# Altium schematic sheet ADC.SchDoc(ADC)
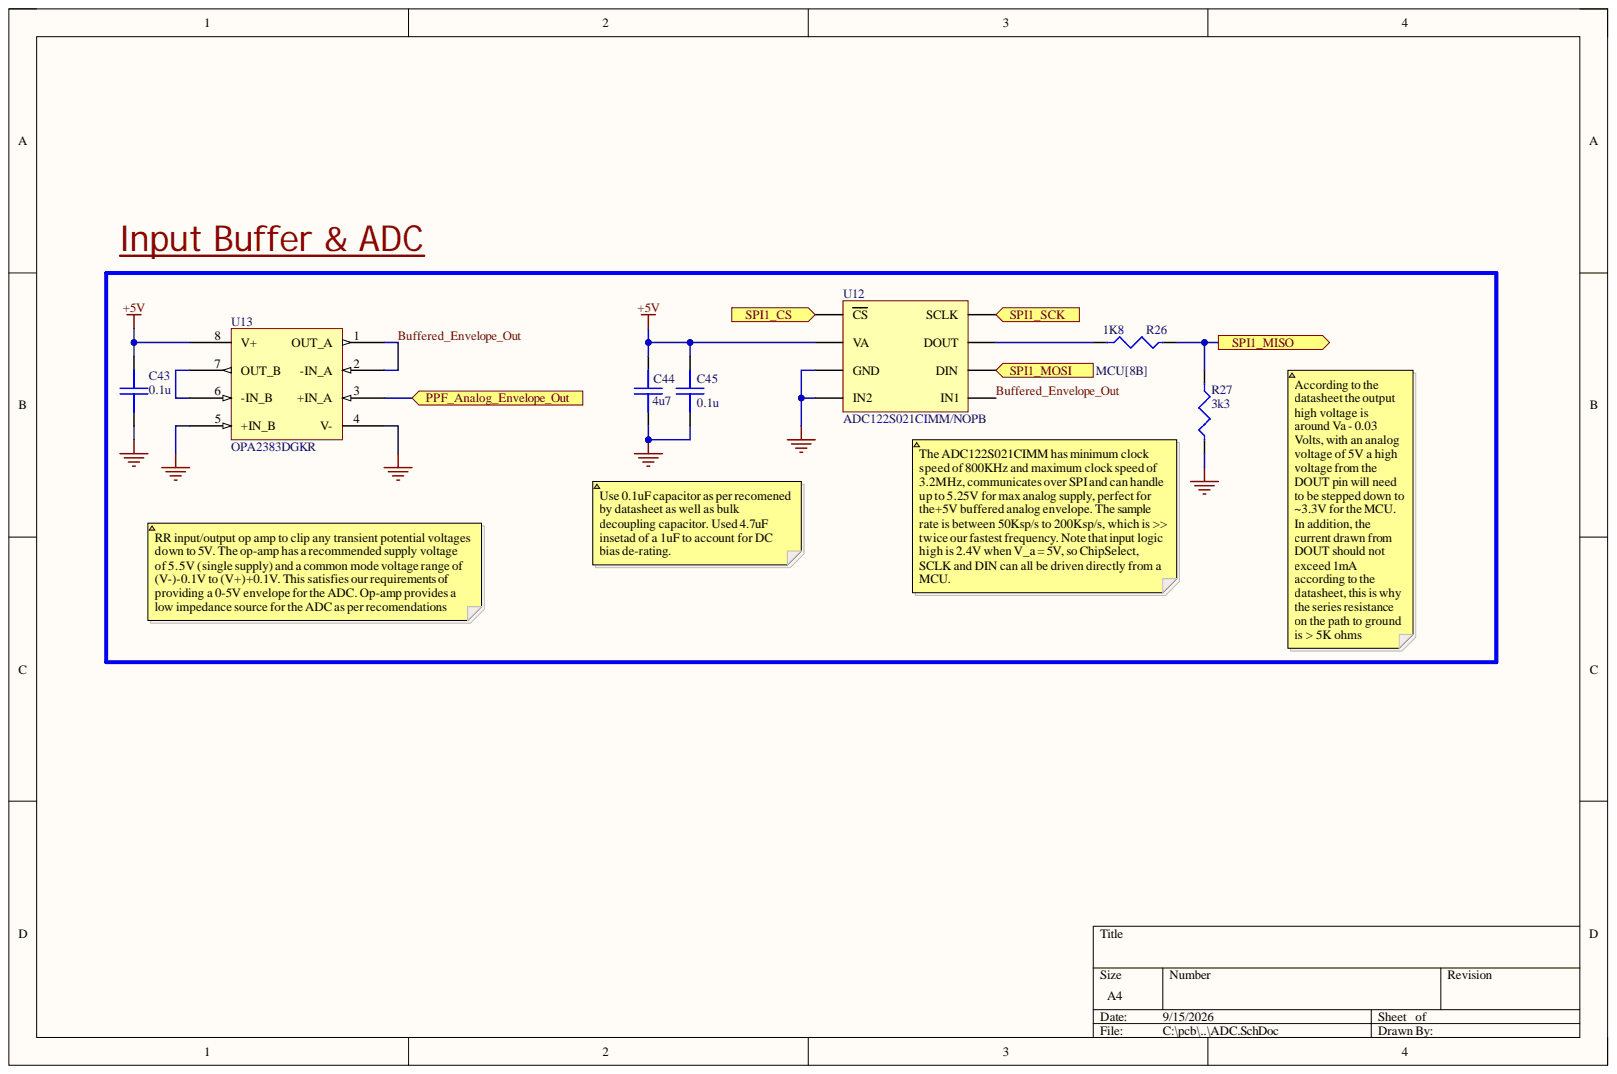
[diagram p0: ADC.SchDoc]

## Parts
C43  0.1u  [C0603]
C44  4u7  [C0805]
C45  0.1u  [C0603]
R26  1K8  [R0603]
R27  3k3  [R0603]
U12  ADC122S021CIMM/NOPB  [ADC122S021CIMM/NOPB]
U13  OPA2383DGKR  [OPA2383DGKR]

## Ports
PPF_Analog_Envelope_Out, SPI1_CS, SPI1_MISO, SPI1_MOSI, SPI1_SCK

## Nets
+5V: C43.2 C44.2 C45.2 U12.2(VA) U13.8(V+)
GND: C43.1 C44.1 C45.1 R27.1 U12.3(GND) U12.4(IN2) U13.4(V-) U13.5(+IN_B)
Buffered_Envelope_Out: U12.5(IN1) U13.1(OUT_A) U13.2(-IN_A)
PPF_Analog_Envelope_Out: U13.3(+IN_A) ; port PPF_Analog_Envelope_Out
SPI1_CS: U12.1(CS) ; port SPI1_CS
SPI1_MISO: R26.1 R27.2 ; port SPI1_MISO
SPI1_MOSI: U12.6(DIN) ; port SPI1_MOSI
SPI1_SCK: U12.8(SCLK) ; port SPI1_SCK
NetR26_2: R26.2 U12.7(DOUT)
NetU13_6: U13.6(-IN_B) U13.7(OUT_B)

## Library data of the parts
C43 C45 = C0603C104K5RACAUTO: Multilayer Ceramic Capacitors MLCC - SMD/SMT 50V 0.1uF X7R 0603 10% AEC-Q200; Manufacturer: Yageo Group; Dissipation Factor: 2.5%; Temperature Coefficient: 30ppm/°C; Value: 100nF; Case Code (Imperial): 0603; Case Code (Metric): 1608; Case/Package: 0603; Category: Ceramic Capacitors; China RoHS: Compliant; Component Kind: Standard; ComponentLink1Description: https://datasheet.octopart.com:443/C0603C104K5RACAUTO-KEMET-datasheet-178087915.pdf?src-supplier=Farnell; ComponentLink1URL: https://datasheet.octopart.com:443/C0603C104K5RACAUTO-KEMET-datasheet-178087915.pdf?src-supplier=Farnell; ComponentLink2Description: https://datasheet.octopart.com:443/C0603C104K5RACAUTO-KEMET-datasheet-140480346.pdf?src-supplier=Farnell; ComponentLink2URL: https://datasheet.octopart.com:443/C0603C104K5RACAUTO-KEMET-datasheet-140480346.pdf?src-supplier=Farnell; ComponentLink3Description: https://datasheet.octopart.com:443/C0603C104K5RACAUTO-KEMET-datasheet-138896278.pdf?src-supplier=Farnell; ComponentLink3URL: https://datasheet.octopart.com:443/C0603C104K5RACAUTO-KEMET-datasheet-138896278.pdf?src-supplier=Farnell; ComponentLink4Description: https://datasheet.octopart.com:443/C0603C104K5RACAUTO-KEMET-datasheet-101575653.pdf?src-supplier=Farnell; ComponentLink4URL: https://datasheet.octopart.com:443/C0603C104K5RACAUTO-KEMET-datasheet-101575653.pdf?src-supplier=Farnell; ComponentLink5Description: https://datasheet.octopart.com:443/C0603C104K5RACAUTO-KEMET-datasheet-169773475.pdf?src-supplier=Farnell; ComponentLink5URL: https://datasheet.octopart.com:443/C0603C104K5RACAUTO-KEMET-datasheet-169773475.pdf?src-supplier=Farnell; ComponentLink6Description: https://datasheet.octopart.com:443/C0603C104K5RAC-AUTO-Kemet-datasheet-11517047.pdf?src-supplier=Farnell; ComponentLink6URL: https://datasheet.octopart.com:443/C0603C104K5RAC-AUTO-Kemet-datasheet-11517047.pdf?src-supplier=Farnell; ComponentLink7Description: https://datasheet.octopart.com:443/C0603C104K5RACAUTO-KEMET-datasheet-137357764.pdf?src-supplier=Upverter; ComponentLink7URL: https://datasheet.octopart.com:443/C0603C104K5RACAUTO-KEMET-datasheet-137357764.pdf?src-supplier=Upverter; ComponentLink8Description: https://datasheet.octopart.com:443/C0603C104K5RACAUTO-Kemet-datasheet-17277841.pdf?src-supplier=Newark; ComponentLink8URL: https://datasheet.octopart.com:443/C0603C104K5RACAUTO-Kemet-datasheet-17277841.pdf?src-supplier=Newark; ComponentLink9Description: https://datasheet.octopart.com:443/C0603C104K5RAC-AUTO-Kemet-datasheet-10850653.pdf?src-supplier=Newark; ComponentLink9URL: https://datasheet.octopart.com:443/C0603C104K5RAC-AUTO-Kemet-datasheet-10850653.pdf?src-supplier=Newark; ComponentLink10Description: https://datasheet.octopart.com:443/C0603C104K5RACAUTO-KEMET-datasheet-176289396.pdf?src-supplier=JRH+Electronics; ComponentLink10URL: https://datasheet.octopart.com:443/C0603C104K5RACAUTO-KEMET-datasheet-176289396.pdf?src-supplier=JRH+Electronics; ComponentLink11Description: https://datasheet.octopart.com:443/C0603C104K5RACAUTO-KEMET-datasheet-153410967.pdf?src-supplier=Electro+Sonic; ComponentLink11URL: https://datasheet.octopart.com:443/C0603C104K5RACAUTO-KEMET-datasheet-153410967.pdf?src-supplier=Electro+Sonic; ComponentLink12Description: https://datasheet.octopart.com:443/C0603C104K5RACAUTO-KEMET-datasheet-142166320.pdf?src-supplier=Electro+Sonic; ComponentLink12URL: https://datasheet.octopart.com:443/C0603C104K5RACAUTO-KEMET-datasheet-142166320.pdf?src-supplier=Electro+Sonic; ComponentLink13Description: https://datasheet.octopart.com:443/C0603C104K5RACAUTO-Kemet-datasheet-66076775.pdf?src-supplier=element14+APAC; ComponentLink13URL: https://datasheet.octopart.com:443/C0603C104K5RACAUTO-Kemet-datasheet-66076775.pdf?src-supplier=element14+APAC; ComponentLink14Description: https://datasheet.octopart.com:443/C0603C104K5RACAUTO-Kemet-datasheet-66204749.pdf?src-supplier=element14+APAC; ComponentLink14URL: https://datasheet.octopart.com:443/C0603C104K5RACAUTO-Kemet-datasheet-66204749.pdf?src-supplier=element14+APAC; ComponentLink15Description: https://datasheet.octopart.com:443/C0603C104K5RACAUTO-Kemet-datasheet-66534724.pdf?src-supplier=element14+APAC; ComponentLink15URL: https://datasheet.octopart.com:443/C0603C104K5RACAUTO-Kemet-datasheet-66534724.pdf?src-supplier=element14+APAC; ComponentLink16Description: https://datasheet.octopart.com:443/C0603C104K5RACAUTO-KEMET-datasheet-83968784.pdf?src-supplier=TME; ComponentLink16URL: https://datasheet.octopart.com:443/C0603C104K5RACAUTO-KEMET-datasheet-83968784.pdf?src-supplier=TME; ComponentLink17Description: https://datasheet.octopart.com:443/C0603C104K5RACAUTO-KEMET-datasheet-83186888.pdf?src-supplier=TME; ComponentLink17URL: https://datasheet.octopart.com:443/C0603C104K5RACAUTO-KEMET-datasheet-83186888.pdf?src-supplier=TME; ComponentLink18Description: https://datasheet.octopart.com/C0603C104K5RACAUTO-KEMET-datasheet-84169754.pdf; ComponentLink18URL: https://datasheet.octopart.com/C0603C104K5RACAUTO-KEMET-datasheet-84169754.pdf; ComponentLink19Description: https://datasheet.octopart.com:443/C0603C104K5RACAUTO-KEMET-datasheet-189767472.pdf?src-supplier=ODG+(Origin+Data+Global); ComponentLink19URL: https://datasheet.octopart.com:443/C0603C104K5RACAUTO-KEMET-datasheet-189767472.pdf?src-supplier=ODG+(Origin+Data+Global); ComponentLink20Description: http://sigma.octopart.com:80/conflict_mineral_statement/Yageo-company-3.pdf?src-supplier=Compliance+Statements; ComponentLink20URL: http://sigma.octopart.com:80/conflict_mineral_statement/Yageo-company-3.pdf?src-supplier=Compliance+Statements; ComponentLink21Description: http://sigma.octopart.com:80/rohs_statement/Yageo-company-2.pdf?src-supplier=Compliance+Statements; ComponentLink21URL: http://sigma.octopart.com:80/rohs_statement/Yageo-company-2.pdf?src-supplier=Compliance+Statements; Contact Plating: Tin; Country of Origin: Mexico; Depth: 800µm; Dielectric: X7R; Dielectric Material: Ceramic; Export Control Classification Number (ECCN) Code: EAR99; Harmonized Tariff Schedule (HTS) Code: 8532.24.00.20; Height: 800µm; Introduction Date: 2011-07-27; Length: 1.6mm; Lifecycle Status: Production; Manufacturer Part Number: C0603C104K5RACAUTO; Material: Ceramic; Max Operating Temperature: 125°C; Min Operating Temperature: -55°C; Mounting Technology: Surface Mount; Number of Terminals: 2; Packaging: Cut Tape; Pricing: 1=0.15, 10=0.083, 50=0.0574, 100=0.0497, 500=0.0367 (USD); Radiation Hardening: No; Ratings: AEC-Q200; REACH SVHC: Yes; RoHS: Compliant; Schedule B: 8532240020, 8532240020|8532240020, 8532240020|8532240020|8532240020, 8532240020|8532240020|8532240020|8532240020; Stock: 1408607; Supplier: DigiKey; Supplier Part Number: 399-6856-6-ND; Termination: SMD/SMT; Thickness: 863.6µm; Tolerance: 10%; Voltage Rating: 50V; Voltage Rating (DC): 50V; Width: 800µm; Library Name: Tremelo_Pedal.SchLib
C44 = TMK212AB7475KG-T: 25V 4.7uF X7R 卤10% 0805 Multilayer Ceramic Capacitors MLCC - SMD/SMT ROHS; Manufacturer: TAIYO YUDEN; Manufacturer Package Identifier: 0805 (2012 metric); Tolerance: 10%; Value: 4.7µF; Case Code (Imperial): 0805; Case/Package: 0805; Category: Ceramic Capacitors; Component Kind: Standard; ComponentLink1Description: https://datasheet.octopart.com:443/TMK212AB7475KG-T-Taiyo-Yuden-datasheet-181961943.pdf?src-supplier=Newark; ComponentLink1URL: https://datasheet.octopart.com:443/TMK212AB7475KG-T-Taiyo-Yuden-datasheet-181961943.pdf?src-supplier=Newark; ComponentLink2Description: https://datasheet.octopart.com:443/TMK212AB7475KG-T-Taiyo-Yuden-datasheet-23715841.pdf?src-supplier=Newark; ComponentLink2URL: https://datasheet.octopart.com:443/TMK212AB7475KG-T-Taiyo-Yuden-datasheet-23715841.pdf?src-supplier=Newark; ComponentLink3Description: https://datasheet.octopart.com:443/TMK212AB7475KG-T-Taiyo-Yuden-datasheet-151625811.pdf?src-supplier=Newark; ComponentLink3URL: https://datasheet.octopart.com:443/TMK212AB7475KG-T-Taiyo-Yuden-datasheet-151625811.pdf?src-supplier=Newark; ComponentLink4Description: https://datasheet.octopart.com:443/TMK212AB7475KG-T-Taiyo-Yuden-datasheet-10851928.pdf?src-supplier=element14+APAC; ComponentLink4URL: https://datasheet.octopart.com:443/TMK212AB7475KG-T-Taiyo-Yuden-datasheet-10851928.pdf?src-supplier=element14+APAC; ComponentLink5Description: https://datasheet.octopart.com:443/TMK212AB7475KG-T-Taiyo-Yuden-datasheet-154810072.pdf?src-supplier=Master+Electronics; ComponentLink5URL: https://datasheet.octopart.com:443/TMK212AB7475KG-T-Taiyo-Yuden-datasheet-154810072.pdf?src-supplier=Master+Electronics; ComponentLink6Description: https://datasheet.octopart.com:443/TMK212AB7475KG-T-Taiyo-Yuden-datasheet-156880150.pdf?src-supplier=Future+Electronics; ComponentLink6URL: https://datasheet.octopart.com:443/TMK212AB7475KG-T-Taiyo-Yuden-datasheet-156880150.pdf?src-supplier=Future+Electronics; ComponentLink7Description: https://datasheet.octopart.com:443/TMK212AB7475KG-T-Taiyo-Yuden-datasheet-133511635.pdf?src-supplier=Future+Electronics; ComponentLink7URL: https://datasheet.octopart.com:443/TMK212AB7475KG-T-Taiyo-Yuden-datasheet-133511635.pdf?src-supplier=Future+Electronics; ComponentLink8Description: https://datasheet.octopart.com:443/TMK212AB7475KG-T-Taiyo-Yuden-datasheet-99784822.pdf?src-supplier=Future+Electronics; ComponentLink8URL: https://datasheet.octopart.com:443/TMK212AB7475KG-T-Taiyo-Yuden-datasheet-99784822.pdf?src-supplier=Future+Electronics; ComponentLink9Description: https://datasheet.octopart.com:443/TMK212AB7475KG-T-Taiyo-Yuden-datasheet-138738940.pdf?src-supplier=Taiyo+Yuden+SCT; ComponentLink9URL: https://datasheet.octopart.com:443/TMK212AB7475KG-T-Taiyo-Yuden-datasheet-138738940.pdf?src-supplier=Taiyo+Yuden+SCT; ComponentLink10Description: https://datasheet.octopart.com:443/TMK212AB7475KG-T-Taiyo-Yuden-datasheet-62109611.pdf?src-supplier=Electro+Sonic; ComponentLink10URL: https://datasheet.octopart.com:443/TMK212AB7475KG-T-Taiyo-Yuden-datasheet-62109611.pdf?src-supplier=Electro+Sonic; ComponentLink11Description: https://datasheet.octopart.com:443/TMK212AB7475KG-T-Taiyo-Yuden-datasheet-41181870.pdf?src-supplier=Electro+Sonic; ComponentLink11URL: https://datasheet.octopart.com:443/TMK212AB7475KG-T-Taiyo-Yuden-datasheet-41181870.pdf?src-supplier=Electro+Sonic; ComponentLink12Description: https://datasheet.octopart.com:443/TMK212AB7475KG-T-Taiyo-Yuden-datasheet-13698275.pdf?src-supplier=_legacy+Avnet; ComponentLink12URL: https://datasheet.octopart.com:443/TMK212AB7475KG-T-Taiyo-Yuden-datasheet-13698275.pdf?src-supplier=_legacy+Avnet; Composition: Ceramic; Contact Plating: Tin; Country of Origin: Japan, Mainland China, Malaysia, South Korea; Depth: 1.25mm; Dielectric: X7R; Dielectric Material: Ceramic; Export Control Classification Number (ECCN) Code: EAR99; Harmonized Tariff Schedule (HTS) Code: 8532.24.00.20; Height: 1.25mm; Introduction Date: 2011-08-29; Length: 2mm; Lifecycle Status: Production; Manufacturer Part Number: TMK212AB7475KG-T; Material: Ceramic; Max Operating Temperature: 125°C; Min Operating Temperature: -55°C; Mounting Technology: Surface Mount; Number of Terminals: 2; Pins: 2; Pricing: 1=0.25, 10=0.147, 50=0.1056, 100=0.0929, 500=0.07164 (USD); Radiation Hardening: No; REACH SVHC: Yes; RoHS: Compliant; Stock: 787349; Supplier: DigiKey; Supplier Part Number: 587-2990-6-ND; Termination: SMD/SMT; Thickness: 1.3462mm; Voltage Rating: 25V; Voltage Rating (DC): 25V; Width: 1.25mm; Library Name: Tremelo_Pedal.SchLib
R26 = CRGCQ0603F1K8: Res Thick Film 0603 1.8K Ohm 1% 0.1W(1/10W) ±100ppm/C Pad SMD Automotive T/R; Manufacturer: TE Connectivity; Manufacturer Lifecycle Status: ACTIVE; Operating Supply Voltage: 75V; Power Rating: 100mW; Temperature Coefficient: 100ppm/°C; Value: 1.8kΩ; Ambient Temperature Range High: 70°C; Case/Package: 0603; Category: Chip SMD Resistors; China RoHS: Non-Compliant; Component Kind: Standard; ComponentLink1Description: https://datasheet.octopart.com:443/CRGCQ0603F1K8-TE-Connectivity-datasheet-114679081.pdf?src-supplier=JRH+Electronics; ComponentLink1URL: https://datasheet.octopart.com:443/CRGCQ0603F1K8-TE-Connectivity-datasheet-114679081.pdf?src-supplier=JRH+Electronics; ComponentLink2Description: https://datasheet.octopart.com:443/CRGCQ0603F1K8-TE-Connectivity-datasheet-179146970.pdf?src-supplier=IHS; ComponentLink2URL: https://datasheet.octopart.com:443/CRGCQ0603F1K8-TE-Connectivity-datasheet-179146970.pdf?src-supplier=IHS; ComponentLink3Description: https://sigma.octopart.com:443/114683329/technical_drawing/TE-Connectivity-CRGCQ0603F1K8.pdf?src-supplier=Sager+Electronics; ComponentLink3URL: https://sigma.octopart.com:443/114683329/technical_drawing/TE-Connectivity-CRGCQ0603F1K8.pdf?src-supplier=Sager+Electronics; ComponentLink4Description: https://datasheet.octopart.com:443/2-2176339-8-TE-Connectivity---AMP-datasheet-189108688.pdf?src-supplier=Electro+Sonic; ComponentLink4URL: https://datasheet.octopart.com:443/2-2176339-8-TE-Connectivity---AMP-datasheet-189108688.pdf?src-supplier=Electro+Sonic; Composition: Thick Film; Export Control Classification Number (ECCN) Code: EAR99; Harmonized Tariff Schedule (HTS) Code: 8533.21.00.30; Height: 450µm; Introduction Date: 2018-03-13; Length: 1.6mm; Lifecycle Status: Production; Manufacturer Part Number: CRGCQ0603F1K8; Max Operating Temperature: 155°C; Min Operating Temperature: -55°C; Mounting Technology: Surface Mount; Number of Terminals: 2; Packaging: Cut Tape; Pricing: 1=0.1, 10=0.033, 25=0.0248, 50=0.0202, 100=0.0166, 250=0.01308, 500=0.01104, 1000=0.00944 (USD); Ratings: AEC-Q200; REACH SVHC: Yes; RoHS: Compliant; Schedule B: 8533210025, 8533210025|8533210025|8533210025|8533210025|8533210025, 8533210045; Stock: 33470; Supplier: DigiKey; Supplier Part Number: A129691DKR-ND; Tolerance: 1%; Voltage Rating: 75V; Voltage Rating (DC): 75V; Width: 800µm; Working Voltage: 75V; Library Name: Tremelo_Pedal.SchLib
R27 = CRGCQ0603F3K3: Res Thick Film 0603 3.3K Ohm 1% 0.1W(1/10W) ±100ppm/C Pad SMD T/R Automotive AEC-Q200; Manufacturer: TE Connectivity; Manufacturer Lifecycle Status: ACTIVE; Operating Supply Voltage: 75V; Power Rating: 100mW; Temperature Coefficient: 100ppm/°C; Value: 3.3kΩ; Ambient Temperature Range High: 70°C; Case/Package: 0603; Category: Chip SMD Resistors; China RoHS: Non-Compliant; Component Kind: Standard; ComponentLink1Description: https://datasheet.octopart.com:443/CRGCQ0603F3K3-TE-Connectivity-datasheet-114679081.pdf?src-supplier=JRH+Electronics; ComponentLink1URL: https://datasheet.octopart.com:443/CRGCQ0603F3K3-TE-Connectivity-datasheet-114679081.pdf?src-supplier=JRH+Electronics; ComponentLink2Description: https://datasheet.octopart.com:443/CRGCQ0603F3K3-TE-Connectivity-datasheet-167977687.pdf?src-supplier=IHS; ComponentLink2URL: https://datasheet.octopart.com:443/CRGCQ0603F3K3-TE-Connectivity-datasheet-167977687.pdf?src-supplier=IHS; ComponentLink3Description: https://sigma.octopart.com:443/114683329/technical_drawing/TE-Connectivity-CRGCQ0603F3K3.pdf?src-supplier=Sager+Electronics; ComponentLink3URL: https://sigma.octopart.com:443/114683329/technical_drawing/TE-Connectivity-CRGCQ0603F3K3.pdf?src-supplier=Sager+Electronics; ComponentLink4Description: https://datasheet.octopart.com:443/3-2176339-1-TE-Connectivity---AMP-datasheet-189108688.pdf?src-supplier=Master+Electronics; ComponentLink4URL: https://datasheet.octopart.com:443/3-2176339-1-TE-Connectivity---AMP-datasheet-189108688.pdf?src-supplier=Master+Electronics; Composition: Thick Film; Export Control Classification Number (ECCN) Code: EAR99; Harmonized Tariff Schedule (HTS) Code: 8533.21.00.30; Height: 450µm; Introduction Date: 2018-03-13; Length: 1.6mm; Lifecycle Status: Production; Manufacturer Part Number: CRGCQ0603F3K3; Max Operating Temperature: 155°C; Min Operating Temperature: -55°C; Mounting Technology: Surface Mount; Number of Terminals: 2; Packaging: Cut Tape; Pricing: 1=0.1, 10=0.033, 25=0.0248, 50=0.0202, 100=0.0166, 250=0.01308, 500=0.01104, 1000=0.00944 (USD); Ratings: AEC-Q200; REACH SVHC: Yes; RoHS: Compliant; Schedule B: 8533210025, 8533210025|8533210025|8533210025|8533210025|8533210025, 8533210045; Stock: 26927; Supplier: DigiKey; Supplier Part Number: A129694CT-ND; Tolerance: 1%; Voltage Rating: 75V; Voltage Rating (DC): 75V; Width: 800µm; Working Voltage: 75V; Library Name: Tremelo_Pedal.SchLib
U12 = ADC122S021CIMM/NOPB: 2-Channel Single ADC SAR 200ksps 12-bit Serial 8-Pin VSSOP T/R; Manufacturer: Texas Instruments; Manufacturer Lifecycle Status: ACTIVE; Integral Nonlinearity (INL): -1.1LSB; Interface: QSPI, SPI; Max Supply Voltage: 5.25V; Min Supply Voltage: 2.7V; Nominal Supply Current: 1.5mA; Number of A/D Converters: 1; Number of Analog Inputs: 2; Number of Bits: 12; Operating Supply Voltage: 5V; Polarity: Unipolar; Power Consumption: 2.2mW; Power Dissipation: 18µW; Resolution: 1.5B; Signal to Noise Ratio (SNR): 72dB; Case/Package: VSSOP; Category: Analog to Digital Converters (ADCs); Component Kind: Standard; ComponentLink1Description: https://sigma.octopart.com:443/178522223/technical_drawing/Texas-Instruments-ADC122S021CIMM-NOPB.pdf?src-supplier=Texas+Instruments; ComponentLink1URL: https://sigma.octopart.com:443/178522223/technical_drawing/Texas-Instruments-ADC122S021CIMM-NOPB.pdf?src-supplier=Texas+Instruments; ComponentLink2Description: https://octopart.com:443/opatz8j6/c1?t=jumMQjirzjuhyYx6wTr41g72WZEtSyyJ0vR7pvHr2zHvmi5hPFIYjqr6GM0fS_mTM1D7BSZAq314kBa9wV7qruxe7NBMjMz04RhmNI-sOLqJGXI6hCNu7WA3vULpqXkKw-R9RUEucnGetppBkFXDGFakr8cs6noppJxfO87NVMQ8S0kjybVkHOXZhYPonVwIP1lb9EDV2pXLH83m2EB445dGQopZTZLhAVIoqZCnEy6R0tM7mTODo70ilhjoQI2i3nW5zOJxZUv3tGn12MW5rFy9KxCNH4xZuOs97HFO1aVleGfltRGUcgZgy7JBQygnSVA6kpGPlJzug3AZ9hUF5ZYaVwgE__QTEpOYWKv0VG62YewDr35cPEzZDl-81hlPn2hloBdBdZM&src-supplier=Texas+Instruments; ComponentLink2URL: https://octopart.com:443/opatz8j6/c1?t=jumMQjirzjuhyYx6wTr41g72WZEtSyyJ0vR7pvHr2zHvmi5hPFIYjqr6GM0fS_mTM1D7BSZAq314kBa9wV7qruxe7NBMjMz04RhmNI-sOLqJGXI6hCNu7WA3vULpqXkKw-R9RUEucnGetppBkFXDGFakr8cs6noppJxfO87NVMQ8S0kjybVkHOXZhYPonVwIP1lb9EDV2pXLH83m2EB445dGQopZTZLhAVIoqZCnEy6R0tM7mTODo70ilhjoQI2i3nW5zOJxZUv3tGn12MW5rFy9KxCNH4xZuOs97HFO1aVleGfltRGUcgZgy7JBQygnSVA6kpGPlJzug3AZ9hUF5ZYaVwgE__QTEpOYWKv0VG62YewDr35cPEzZDl-81hlPn2hloBdBdZM&src-supplier=Texas+Instruments; ComponentLink3Description: https://datasheet.octopart.com:443/ADC122S021CIMM-NOPB-Texas-Instruments-datasheet-32592263.pdf?src-supplier=Texas+Instruments; ComponentLink3URL: https://datasheet.octopart.com:443/ADC122S021CIMM-NOPB-Texas-Instruments-datasheet-32592263.pdf?src-supplier=Texas+Instruments; ComponentLink4Description: https://datasheet.octopart.com:443/ADC122S021CIMM-NOPB-Texas-Instruments-datasheet-14442122.pdf?src-supplier=Texas+Instruments; ComponentLink4URL: https://datasheet.octopart.com:443/ADC122S021CIMM-NOPB-Texas-Instruments-datasheet-14442122.pdf?src-supplier=Texas+Instruments; ComponentLink5Description: https://sigma.octopart.com:443/49250154/technical_drawing/Texas-Instruments-ADC122S021CIMM-NOPB.pdf?src-supplier=Texas+Instruments; ComponentLink5URL: https://sigma.octopart.com:443/49250154/technical_drawing/Texas-Instruments-ADC122S021CIMM-NOPB.pdf?src-supplier=Texas+Instruments; ComponentLink6Description: https://datasheet.octopart.com:443/ADC122S021CIMM/NOPB-Texas-Instruments-datasheet-11768566.pdf?src-supplier=Arrow+Electronics; ComponentLink6URL: https://datasheet.octopart.com:443/ADC122S021CIMM/NOPB-Texas-Instruments-datasheet-11768566.pdf?src-supplier=Arrow+Electronics; ComponentLink7Description: https://datasheet.octopart.com:443/ADC122S021CIMM-NOPB-Texas-Instruments-datasheet-62118496.pdf?src-supplier=Farnell; ComponentLink7URL: https://datasheet.octopart.com:443/ADC122S021CIMM-NOPB-Texas-Instruments-datasheet-62118496.pdf?src-supplier=Farnell; ComponentLink8Description: https://datasheet.octopart.com:443/ADC122S021CIMM-NOPB-Texas-Instruments-datasheet-21412508.pdf?src-supplier=Farnell; ComponentLink8URL: https://datasheet.octopart.com:443/ADC122S021CIMM-NOPB-Texas-Instruments-datasheet-21412508.pdf?src-supplier=Farnell; ComponentLink9Description: https://datasheet.octopart.com:443/ADC122S021CIMM-NOPB-Texas-Instruments-datasheet-164412365.pdf?src-supplier=SHENGYU+ELECTRONICS; ComponentLink9URL: https://datasheet.octopart.com:443/ADC122S021CIMM-NOPB-Texas-Instruments-datasheet-164412365.pdf?src-supplier=SHENGYU+ELECTRONICS; ComponentLink10Description: https://datasheet.octopart.com:443/ADC122S021CIMM-NOPB-Texas-Instruments-datasheet-10846197.pdf?src-supplier=Newark; ComponentLink10URL: https://datasheet.octopart.com:443/ADC122S021CIMM-NOPB-Texas-Instruments-datasheet-10846197.pdf?src-supplier=Newark; ComponentLink11Description: https://datasheet.octopart.com:443/ADC122S021CIMM/NOPB-National-Semiconductor-datasheet-622615.pdf?src-supplier=Future+Electronics; ComponentLink11URL: https://datasheet.octopart.com:443/ADC122S021CIMM/NOPB-National-Semiconductor-datasheet-622615.pdf?src-supplier=Future+Electronics; ComponentLink12Description: http://sigma.octopart.com:80/reach_statement/Texas-Instruments-company-64.pdf?src-supplier=Compliance+Statements; ComponentLink12URL: http://sigma.octopart.com:80/reach_statement/Texas-Instruments-company-64.pdf?src-supplier=Compliance+Statements; ComponentLink13Description: http://sigma.octopart.com:80/conflict_mineral_statement/Texas-Instruments-company-63.pdf?src-supplier=Compliance+Statements; ComponentLink13URL: http://sigma.octopart.com:80/conflict_mineral_statement/Texas-Instruments-company-63.pdf?src-supplier=Compliance+Statements; Contact Plating: Tin; Conversion Rate: 200ksps; Height: 0m; Lead Free: Lead Free; Length: 3mm; Lifecycle Status: Production; Manufacturer Part Number: ADC122S021CIMM/NOPB; Max Operating Temperature: 85°C; Min Operating Temperature: -40°C; Mounting Technology: Surface Mount; Number of Channels: 2; Number of Converters: 1; Number of Elements: 1; Number of Inputs: 2; Packaging: Tape and Reel; Pins: 8; Pricing: 1=3.42, 10=2.57, 25=2.3572, 100=2.1229, 250=2.01128, 500=1.98594 (USD); Radiation Hardening: No; REACH SVHC: No; RoHS: Compliant; Sampling Rate: 200ksps; Schedule B: 8542390000, 8542390000|8542390000|8542390000|8542390000|8542390000; Stock: 2763; Supplier: DigiKey; Supplier Part Number: ADC122S021CIMM/NOPBCT-ND; Supply Type: Single; Thickness: 970µm; Width: 3mm; Library Name: Tremelo_Pedal.SchLib
U13 = OPA2383DGKR: Dual, zero-drift, micropower (65μA), high speed-to-power ratio (2.5MHz) RRIO operational amplifier 8-VSSOP -40 to 125; Manufacturer: Texas Instruments; Manufacturer Lifecycle Status: ACTIVE; Case/Package: VSSOP; Category: Amplifiers - Op Amps, Buffer, Instrumentation; Component Kind: Standard; ComponentLink1Description: https://sigma.octopart.com:443/178522223/technical_drawing/Texas-Instruments-OPA2383DGKR.pdf?src-supplier=Texas+Instruments; ComponentLink1URL: https://sigma.octopart.com:443/178522223/technical_drawing/Texas-Instruments-OPA2383DGKR.pdf?src-supplier=Texas+Instruments; ComponentLink2Description: https://octopart.com:443/opatz8j6/c1?t=YbFiNOjndj07TKcEXZjzwQWc7X_JHFS0PafwkqJ06owmW96jYFsep0tT3B5MhHWR32Fvn3sXC_TH-kDl7ISOWafN26jNb0AApKmeZmainmbi34GMVLDeoPU3SeFaSfCkI4bsTOh9G4HkZ9sh0iwamcuodoobapXRtNWOvV_fvLE-CG_3xDse5GloohCHQ4Gc2Ff6i1T47-jvqD43ug1eg17LvtZkxCyHiKcGyk3_SPS8PIAOv7OHAbCOMmaefBVB6B1D07Hhp1cWobw7eqvAhnyNh_hYGhwVYqz2bTrq9Gye6MzGV5Ccdgv_-3QsH8TqDb1__7s2-ClkF3GCapE5F8P7-NuTdrv9VIjrzwAkfugi-eCw0NswniKgP_spLGDaWQ01tzYS&src-supplier=Texas+Instruments; ComponentLink2URL: https://octopart.com:443/opatz8j6/c1?t=YbFiNOjndj07TKcEXZjzwQWc7X_JHFS0PafwkqJ06owmW96jYFsep0tT3B5MhHWR32Fvn3sXC_TH-kDl7ISOWafN26jNb0AApKmeZmainmbi34GMVLDeoPU3SeFaSfCkI4bsTOh9G4HkZ9sh0iwamcuodoobapXRtNWOvV_fvLE-CG_3xDse5GloohCHQ4Gc2Ff6i1T47-jvqD43ug1eg17LvtZkxCyHiKcGyk3_SPS8PIAOv7OHAbCOMmaefBVB6B1D07Hhp1cWobw7eqvAhnyNh_hYGhwVYqz2bTrq9Gye6MzGV5Ccdgv_-3QsH8TqDb1__7s2-ClkF3GCapE5F8P7-NuTdrv9VIjrzwAkfugi-eCw0NswniKgP_spLGDaWQ01tzYS&src-supplier=Texas+Instruments; ComponentLink3Description: https://datasheet.octopart.com:443/OPA2383DGKR-Texas-Instruments-datasheet-192918492.pdf?src-supplier=LCSC; ComponentLink3URL: https://datasheet.octopart.com:443/OPA2383DGKR-Texas-Instruments-datasheet-192918492.pdf?src-supplier=LCSC; ComponentLink4Description: https://datasheet.octopart.com:443/OPA2383DGKR-Texas-Instruments-datasheet-190364691.pdf?src-supplier=LCSC; ComponentLink4URL: https://datasheet.octopart.com:443/OPA2383DGKR-Texas-Instruments-datasheet-190364691.pdf?src-supplier=LCSC; ComponentLink5Description: http://sigma.octopart.com:80/reach_statement/Texas-Instruments-company-64.pdf?src-supplier=Compliance+Statements; ComponentLink5URL: http://sigma.octopart.com:80/reach_statement/Texas-Instruments-company-64.pdf?src-supplier=Compliance+Statements; ComponentLink6Description: http://sigma.octopart.com:80/conflict_mineral_statement/Texas-Instruments-company-63.pdf?src-supplier=Compliance+Statements; ComponentLink6URL: http://sigma.octopart.com:80/conflict_mineral_statement/Texas-Instruments-company-63.pdf?src-supplier=Compliance+Statements; Height: 0m; Length: 3mm; Lifecycle Status: Production; Manufacturer Part Number: OPA2383DGKR; Pins: 8; Pricing: 1=2.09, 10=1.545, 25=1.4076, 100=1.2567, 250=1.1848, 500=1.14444 (USD); RoHS: Compliant; Stock: 5769; Supplier: DigiKey; Supplier Part Number: 296-OPA2383DGKRDKR-ND; Thickness: 970µm; Width: 3mm; Library Name: Tremelo_Pedal.SchLib
